# Altium schematic sheet SensorLTR390.SchDoc
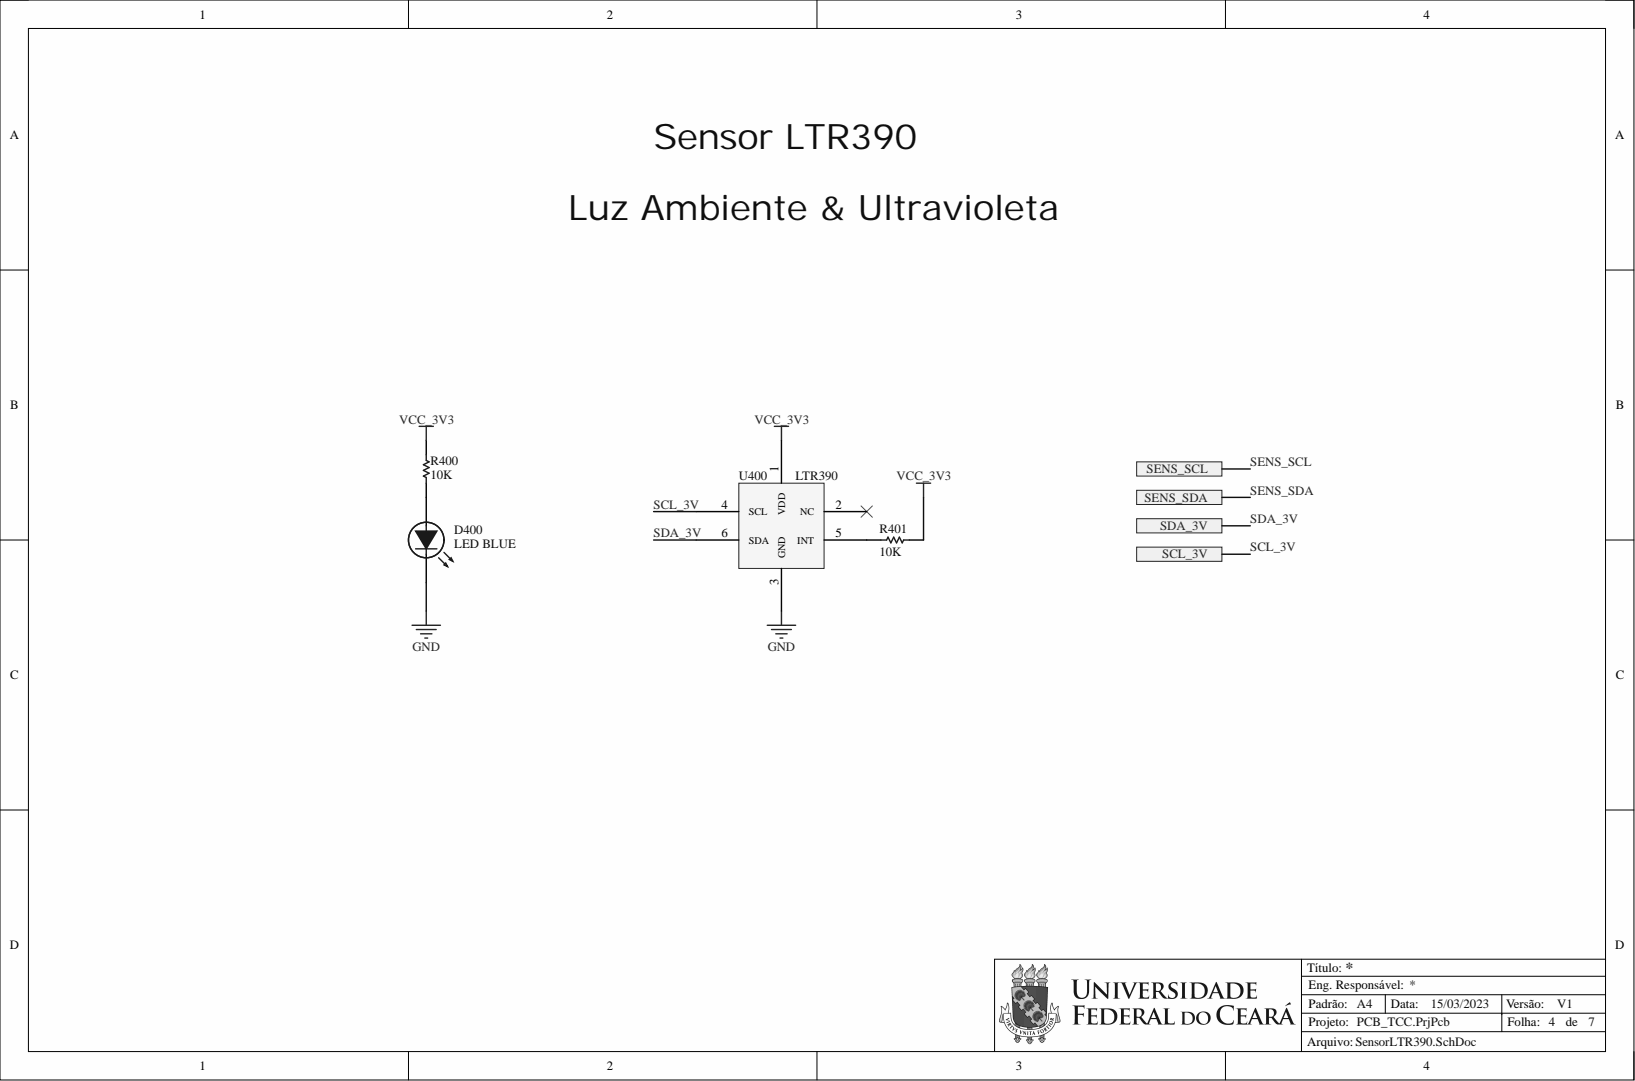
[diagram p0: SensorLTR390.SchDoc]

## Parts
D400  LED BLUE  [LED_BLUE_SMD_0603]
R400  10K  [RES_0603]
R401  10K  [RES_0603]
U400  LTR390  [LTR390]

## Ports
SCL_3V, SDA_3V, SENS_SCL, SENS_SDA

## Nets
GND: D400.K U400.3(GND)
VCC_3V3: R400.2 R401.2 U400.1(VDD)
SCL_3V: U400.4(SCL) ; port SCL_3V
SDA_3V: U400.6(SDA) ; port SDA_3V
NetD400_A: D400.A R400.1
NetR401_1: R401.1 U400.5(INT)
SENS_SCL: ; port SENS_SCL
SENS_SDA: ; port SENS_SDA

Not connected: U400 2(NC)

## Library data of the parts
D400 = LED_BLUE_SMD_0603: Component Kind: Standard; ComponentLink1Description: New link; Supplier 1: Digi-Key; Supplier Part Number 1: 754-1434-1-ND; Library Name: PCB_TCC.SchLib
R400 R401 = RES_10K_0603: Component Kind: Standard; Supplier 1: Digi-Key Marketplace; Supplier Part Number 1: 2571-RM06J103CTTR-ND; Library Name: PCB_TCC.SchLib
U400 = LTR390: Component Kind: Standard; Supplier 1: Digi-Key; Supplier Part Number 1: LTR-390UV-01-ND; Library Name: PCB_TCC.SchLib
